# Altium schematic sheet [5]Driver4.SchDoc
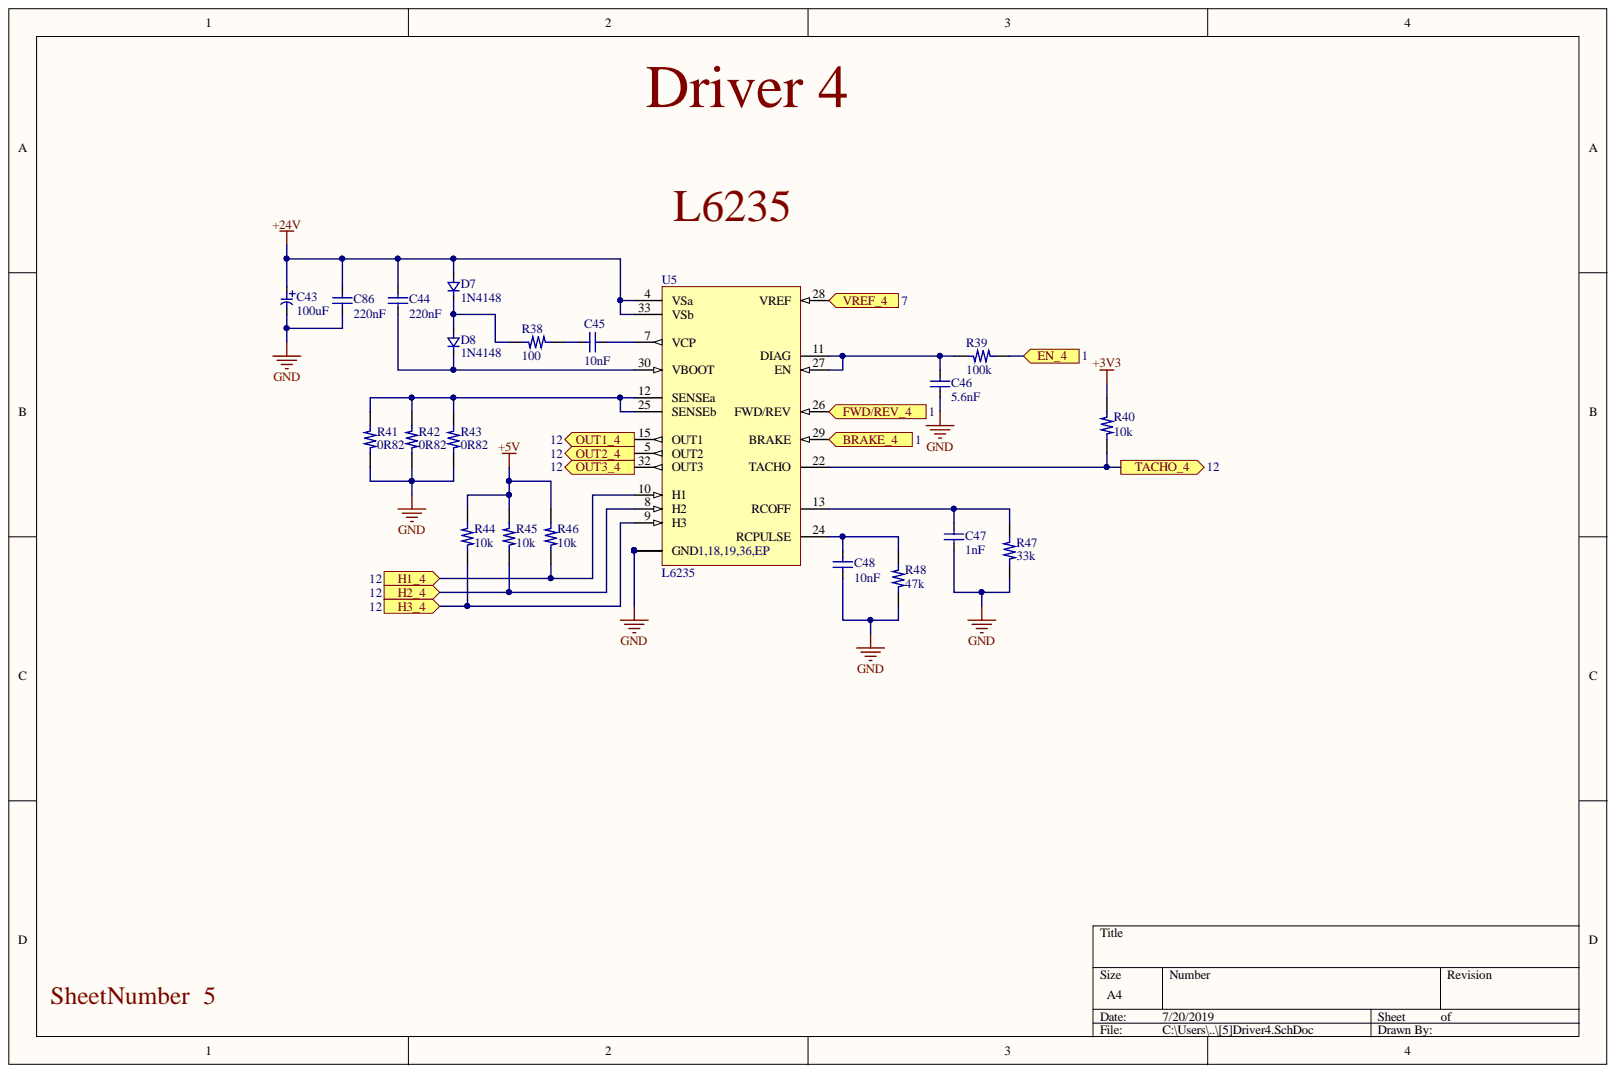
[diagram p0: [5]Driver4.SchDoc]

## Parts
C43  100uF  [Radial SMD 10D]
C44  220nF  [C0805]
C45  10nF  [C0805]
C46  5.6nF  [C0805]
C47  1nF  [C0805]
C48  10nF  [C0805]
C86  220nF  [C0805]
D7  1N4148  [SOD-123]
D8  1N4148  [SOD-123]
R38  100  [R0805]
R39  100k  [R0805]
R40  10k  [R0805]
R41  0R82  [R4122]
R42  0R82  [R4122]
R43  0R82  [R4122]
R44  10k  [R0805]
R45  10k  [R0805]
R46  10k  [R0805]
R47  33k  [R0805]
R48  47k  [R0805]
U5  L6235  [POWERSO36]

## Ports
BRAKE_4, EN_4, FWD/REV_4, H1_4, H2_4, H3_4, OUT1_4, OUT2_4, OUT3_4, TACHO_4, VREF_4

## Nets
+3V3: R40.2
+5V: R44.1 R45.1 R46.1
+24V: C43.1 C44.2 C86.2 D7.1 U5.4(VSa) U5.33(VSb)
GND: C43.2 C46.2 C47.1 C48.1 C86.1 R41.1 R42.1 R43.1 R47.1 R48.1 U5.1 U5.18 U5.19 U5.36 U5.37
BRAKE_4: U5.29(BRAKE) ; port BRAKE_4
EN_4: R39.2 ; port EN_4
FWD/REV_4: U5.26(FWD/REV) ; port FWD/REV_4
H1_4: R46.2 U5.10(H1) ; port H1_4
H2_4: R45.2 U5.8(H2) ; port H2_4
H3_4: R44.2 U5.9(H3) ; port H3_4
OUT1_4: U5.15(OUT1) ; port OUT1_4
OUT2_4: U5.5(OUT2) ; port OUT2_4
OUT3_4: U5.32(OUT3) ; port OUT3_4
TACHO_4: R40.1 U5.22(TACHO) ; port TACHO_4
VREF_4: U5.28(VREF) ; port VREF_4
NetC44_1: C44.1 D8.2 U5.30(VBOOT)
NetC45_1: C45.1 R38.2
NetC45_2: C45.2 U5.7(VCP)
NetC46_1: C46.1 R39.1 U5.11(DIAG) U5.27(EN)
NetC47_2: C47.2 R47.2 U5.13(RCOFF)
NetC48_2: C48.2 R48.2 U5.24(RCPULSE)
NetD7_2: D7.2 D8.1 R38.1
NetR41_2: R41.2 R42.2 R43.2 U5.12(SENSEa) U5.25(SENSEb)

## Library data of the parts
C43 = EEE1JA101P: CAP ALUM 100UF 20% 63V SMD; Mfr 1: Panasonic Electronic Components; Mfr 1 PN: EEE-1JA101P; Component Kind: Standard; Library Name: Components.SchLib
C44 C86 = C0805C224K5RACAUTO: CAP CER 0.22UF 50V X7R 0805; Mfr 1: KEMET; Component Kind: Standard; Library Name: Components.SchLib; Mfr 1 PN: C0805C224K5RACAUTO
C45 C48 = CL21B103KBANFNC: CAP CER 10000PF 50V X7R 0805; Mfr 1: Samsung Electro-Mechanics; Component Kind: Standard; Library Name: Components.SchLib; Mfr 1 PN: CL21B103KBANFNC
C46 = CL21B562KBANNNC: CAP CER 5600PF 50V X7R 0805; Mfr 1: Samsung Electro-Mechanics; Component Kind: Standard; Library Name: Components.SchLib; Mfr 1 PN: CL21B562KBANNNC
C47 = CL21B102KBANFNC: CAP CER 1000PF 50V X7R 0805; Mfr 1: Samsung Electro-Mechanics; Component Kind: Standard; Library Name: Components.SchLib; Mfr 1 PN: CL21B102KBANFNC
D7 D8 = 1N4148W-G RHG: DIODE GEN PURP 100V 150MA SOD123; Mfr 1: Taiwan Semiconductor Corporation; Component Kind: Standard; Library Name: Components.SchLib; Mfr 1 PN: 1N4148W-G RHG
R38 = ESR10EZPF1000: RES SMD 100 OHM 1% 0.4W 0805; Mfr 1: Rohm Semiconductor; Component Kind: Standard; Library Name: Components.SchLib; Mfr 1 PN: ESR10EZPF1000
R39 = RC0805FR-07100KL: RES SMD 100K OHM 1% 1/8W 0805; Mfr 1: Yageo; Component Kind: Standard; Library Name: Components.SchLib; Mfr 1 PN: RC0805FR-07100KL
R40 = CRG0805F10K/10: RES SMD 10K OHM 1% 1/8W 0805; Mfr 1: TE Connectivity Passive Product; Component Kind: Standard; Library Name: Components.SchLib; Mfr 1 PN: CRG0805F10K/10
R41-R43 = SMW3R82JT: RES 0.82 OHM 5% 3W 4122; Mfr 1: TE Connectivity Passive Product; Component Kind: Standard; Library Name: Components.SchLib; Mfr 1 PN: SMW3R82JT
R44-R46 = RC0805FR-0710KL: RES SMD 10K OHM 1% 1/8W 0805; Mfr 1: Yageo; Component Kind: Standard; Library Name: Components.SchLib; Mfr 1 PN: RC0805FR-0710KL
R47 = RMCF0805FT33K0: RES 33K OHM 1% 1/8W 0805; Mfr 1: Stackpole Electronics Inc.; Component Kind: Standard; Library Name: Components.SchLib; Mfr 1 PN: RMCF0805FT33K0
R48 = RC0805FR-0747KL: RES SMD 47K OHM 1% 1/8W 0805; Mfr 1: Yageo; Component Kind: Standard; Library Name: Components.SchLib; Mfr 1 PN: RC0805FR-0747KL
U5 = L6235PD: IC MOTOR DRIVER PAR 36POWERSO; Mfr 1: STMicroelectronics; Component Kind: Standard; Library Name: Components.SchLib; Mfr 1 PN: L6235PD
